# Altium schematic sheet GPS.SchDoc
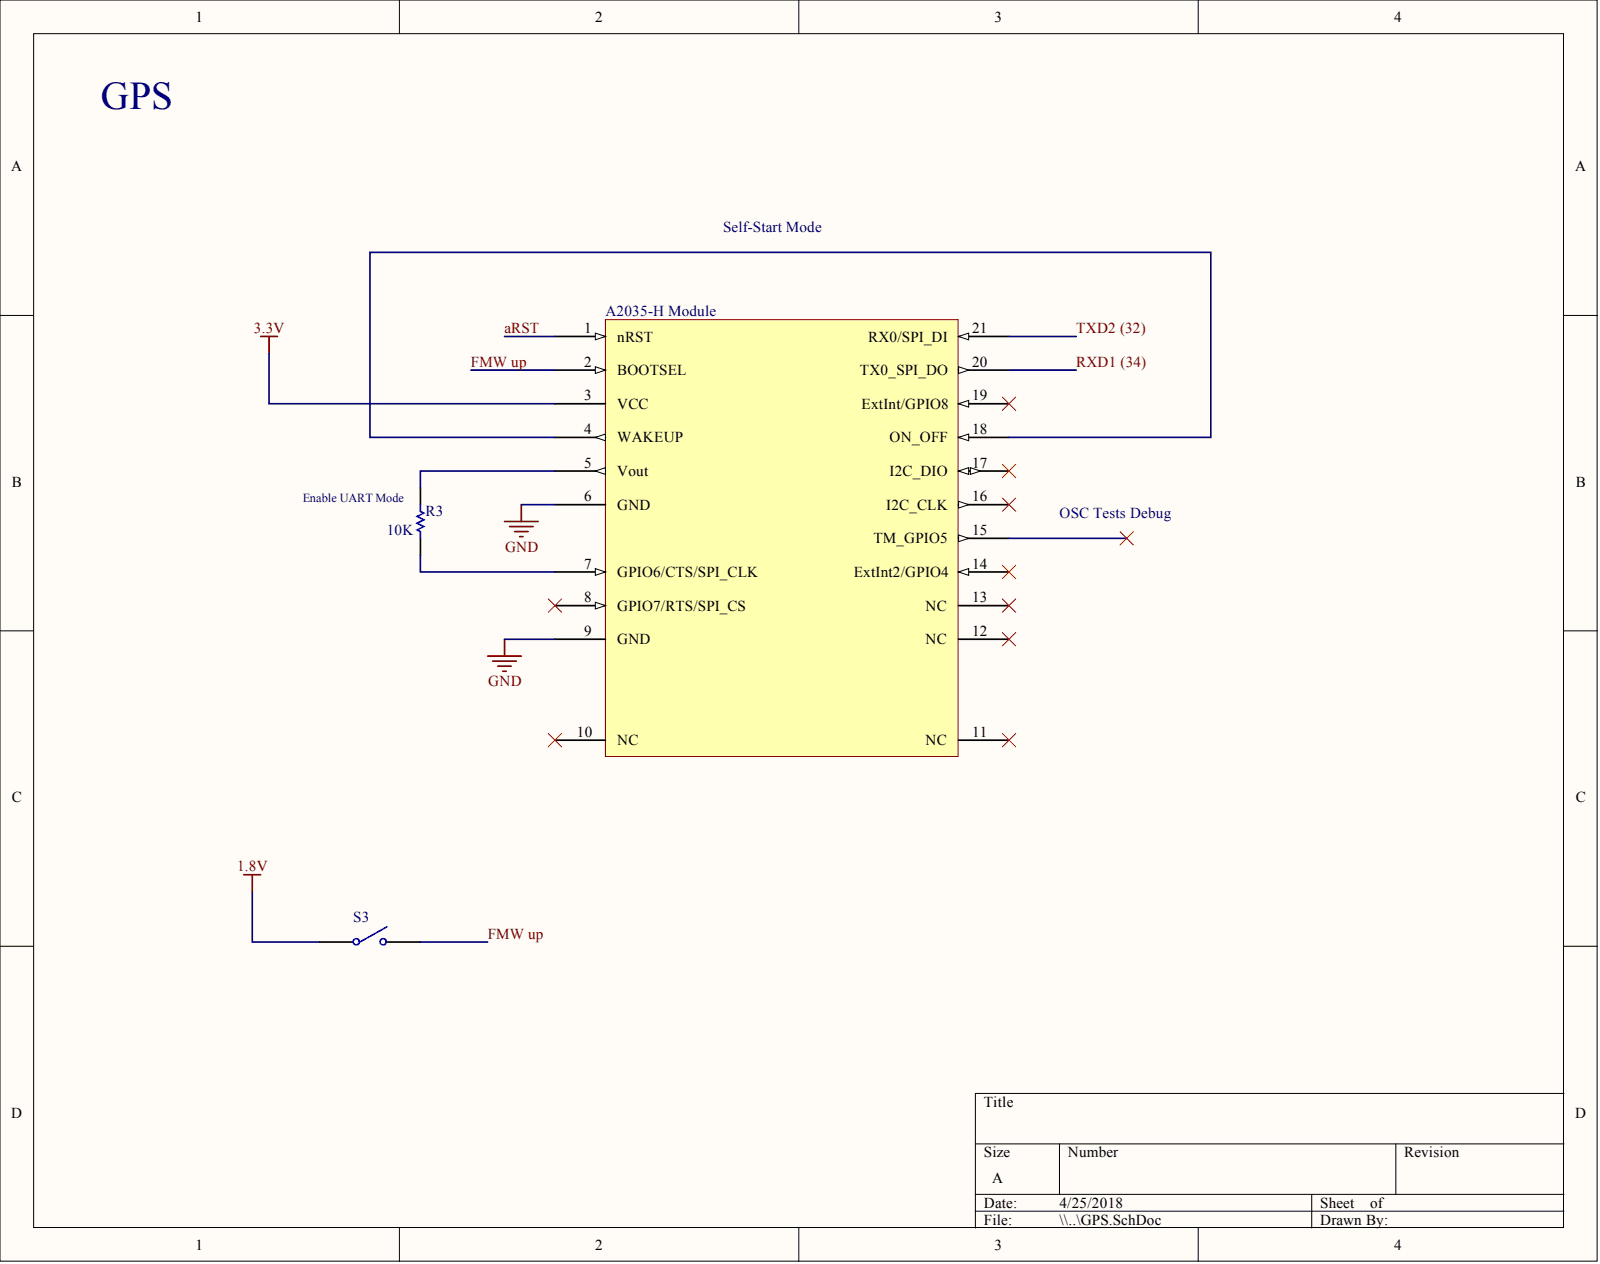
[diagram p0: GPS.SchDoc]

## Parts
A2035-H_Module  A2035-H
R3  value 10K  [AXIAL-0.3]  (hidden comment: Resistor 3)
S3  SW-SPST  [SPST-2]  (value not drawn)

## Nets
1.8V: S3.1
3.3V: A2035-H_Module.3(VCC)
GND: A2035-H_Module.6(GND) A2035-H_Module.9(GND)
ARST: A2035-H_Module.1(nRST)
FMW UP: A2035-H_Module.2(BOOTSEL) S3.2
RXD1 (34): A2035-H_Module.20(TX0_SPI_DO)
TXD2 (32): A2035-H_Module.21(RX0/SPI_DI)
NetA2035-H Module_4: A2035-H_Module.4(WAKEUP) A2035-H_Module.18(ON_OFF)
NetA2035-H Module_5: A2035-H_Module.5(Vout) R3.2
NetA2035-H Module_7: A2035-H_Module.7(GPIO6/CTS/SPI_CLK) R3.1

Not connected: A2035-H_Module 8(GPIO7/RTS/SPI_CS) 10(NC) 11(NC) 12(NC) 13(NC) 14(ExtInt2/GPIO4) 15(TM_GPIO5) 16(I2C_CLK) 17(I2C_DIO) 19(ExtInt/GPIO8)

## Library data of the parts
R3 = Res1: Resistor; Component Kind: Standard; LatestRevisionDate: 17-Jul-2002; LatestRevisionNote: Re-released for DXP Platform.; Library Name: Miscellaneous Devices.IntLib; PackageDescription: Axial Device, Thru-Hole; 2 Leads; 0.3 in Pin Spacing; PackageReference: AXIAL-0.3; Published: 8-Jun-2000; Signal Integrity: Res; Simulation: RESISTOR; Publisher: Altium Limited
S3 = SW-SPST: Single-Pole, Single-Throw Switch; Component Kind: Standard; LatestRevisionDate: 17-Jul-2002; LatestRevisionNote: Re-released for DXP Platform.; Library Name: Miscellaneous Devices.IntLib; PackageDescription: Switch; 2 Leads; PackageReference: SPST-2; Published: 24-Mar-1999; Publisher: Altium Limited
A2035-H_Module = A2035-H: Component Kind: Standard; Library Name: Schlib.SchLib
